# Altium schematic sheet Cell Balancing.SchDoc
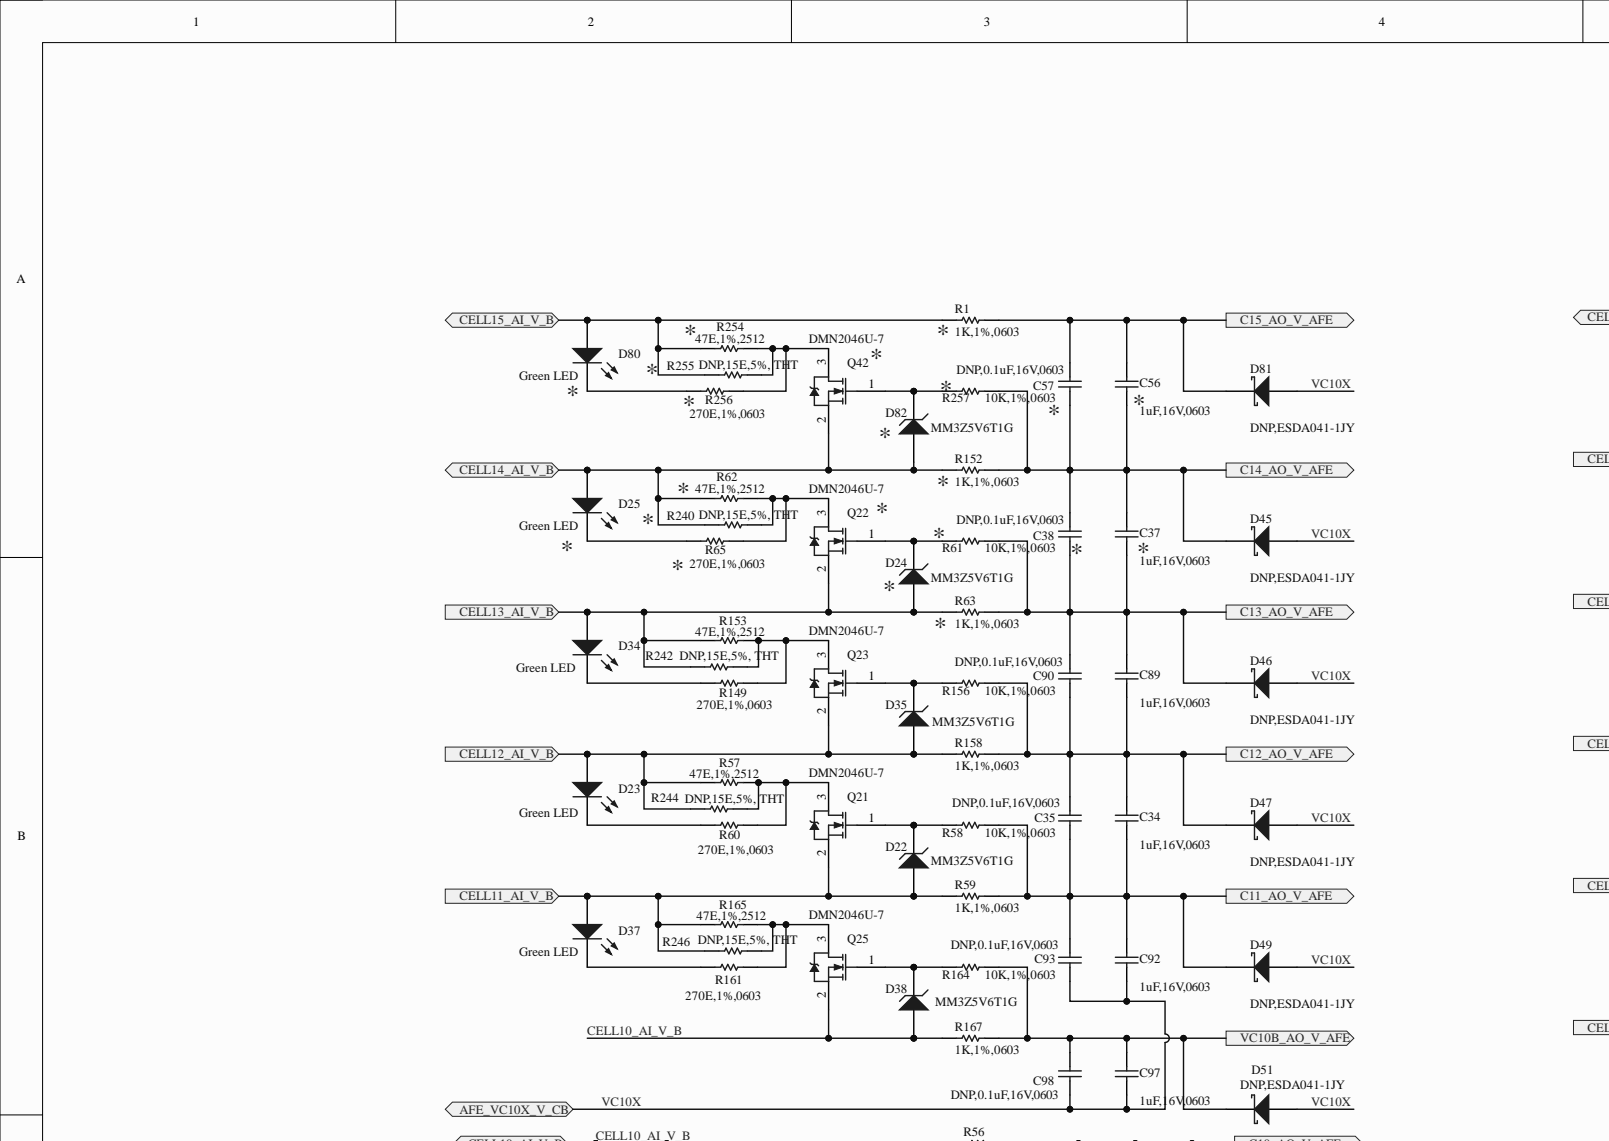
[diagram p0: Cell Balancing.SchDoc, top left]
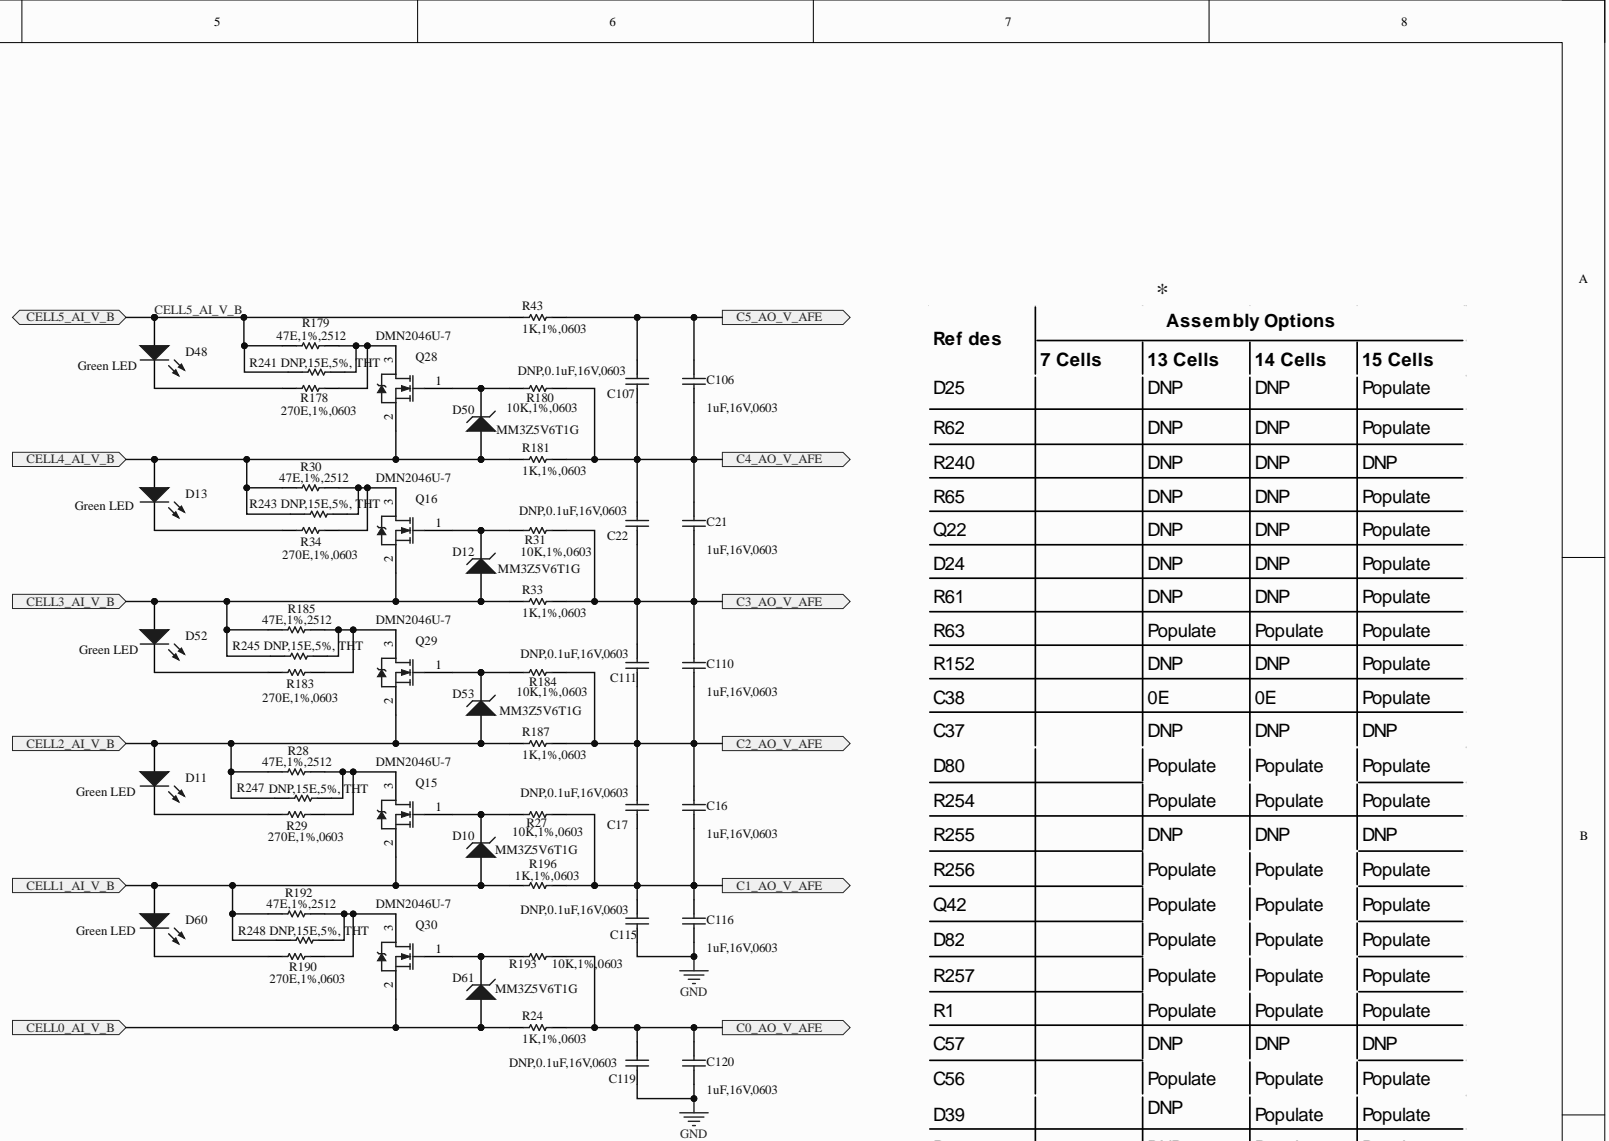
[diagram p1: Cell Balancing.SchDoc, top right]
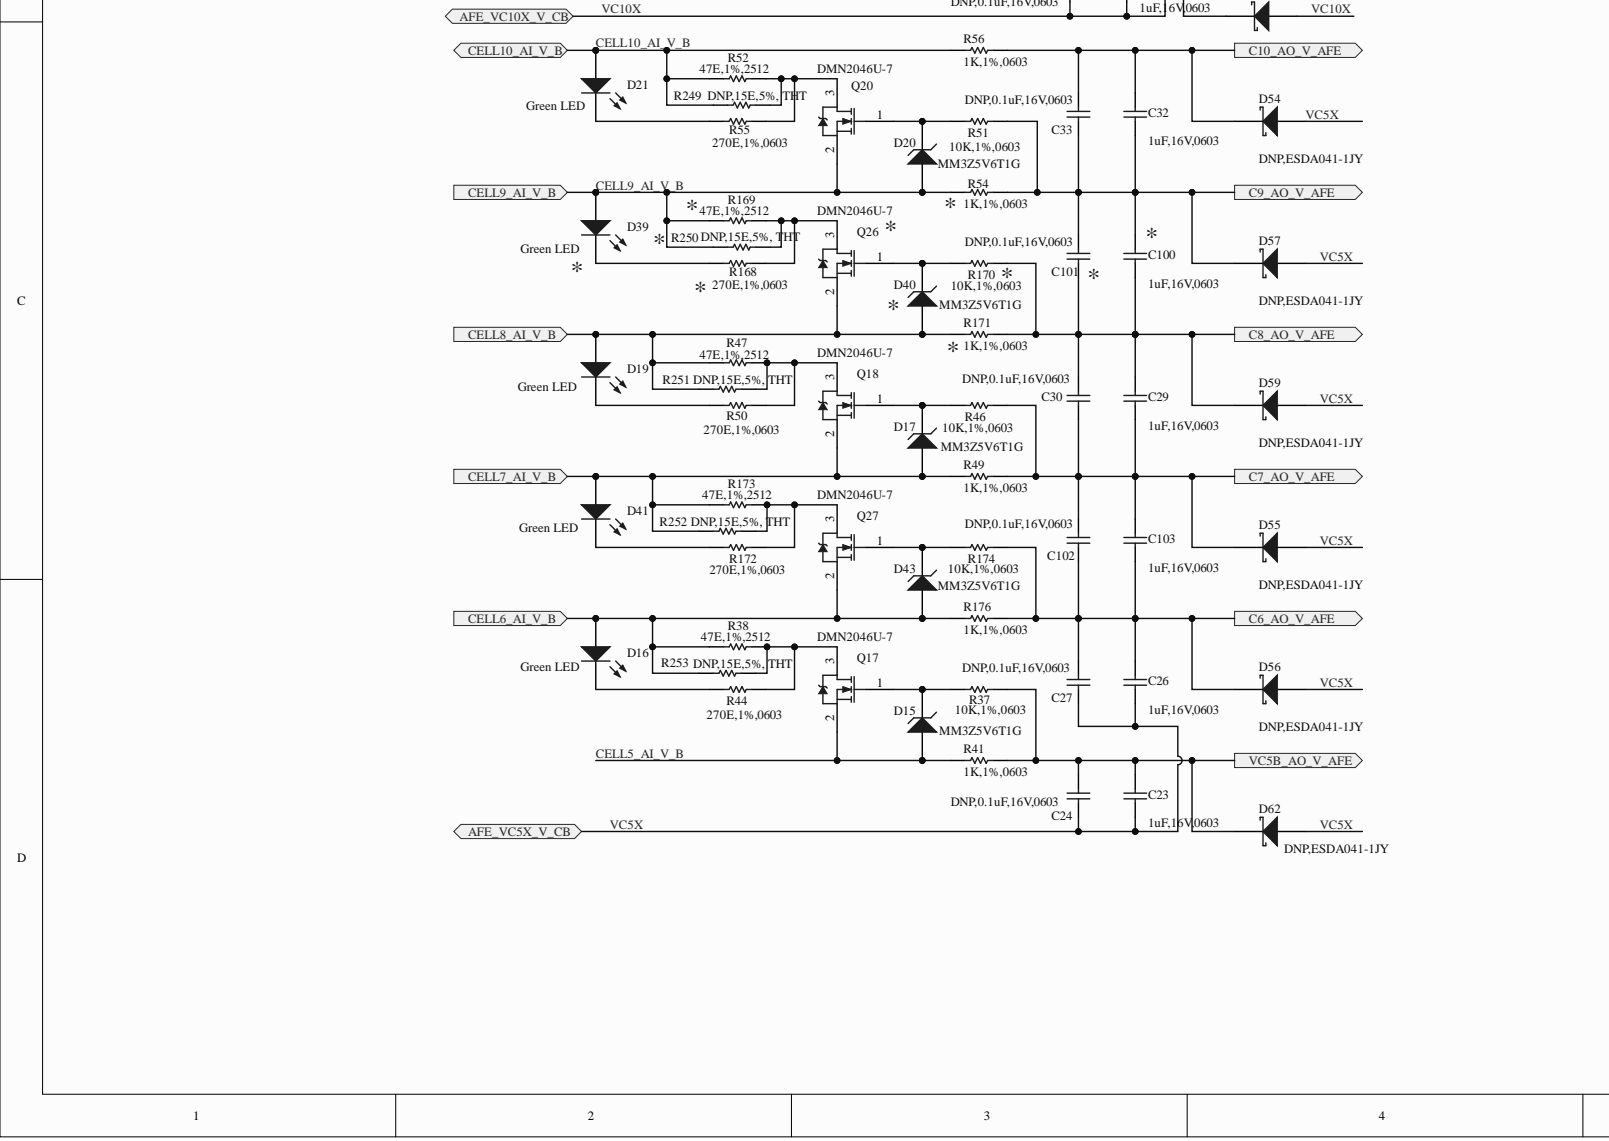
[diagram p2: Cell Balancing.SchDoc, bottom left]
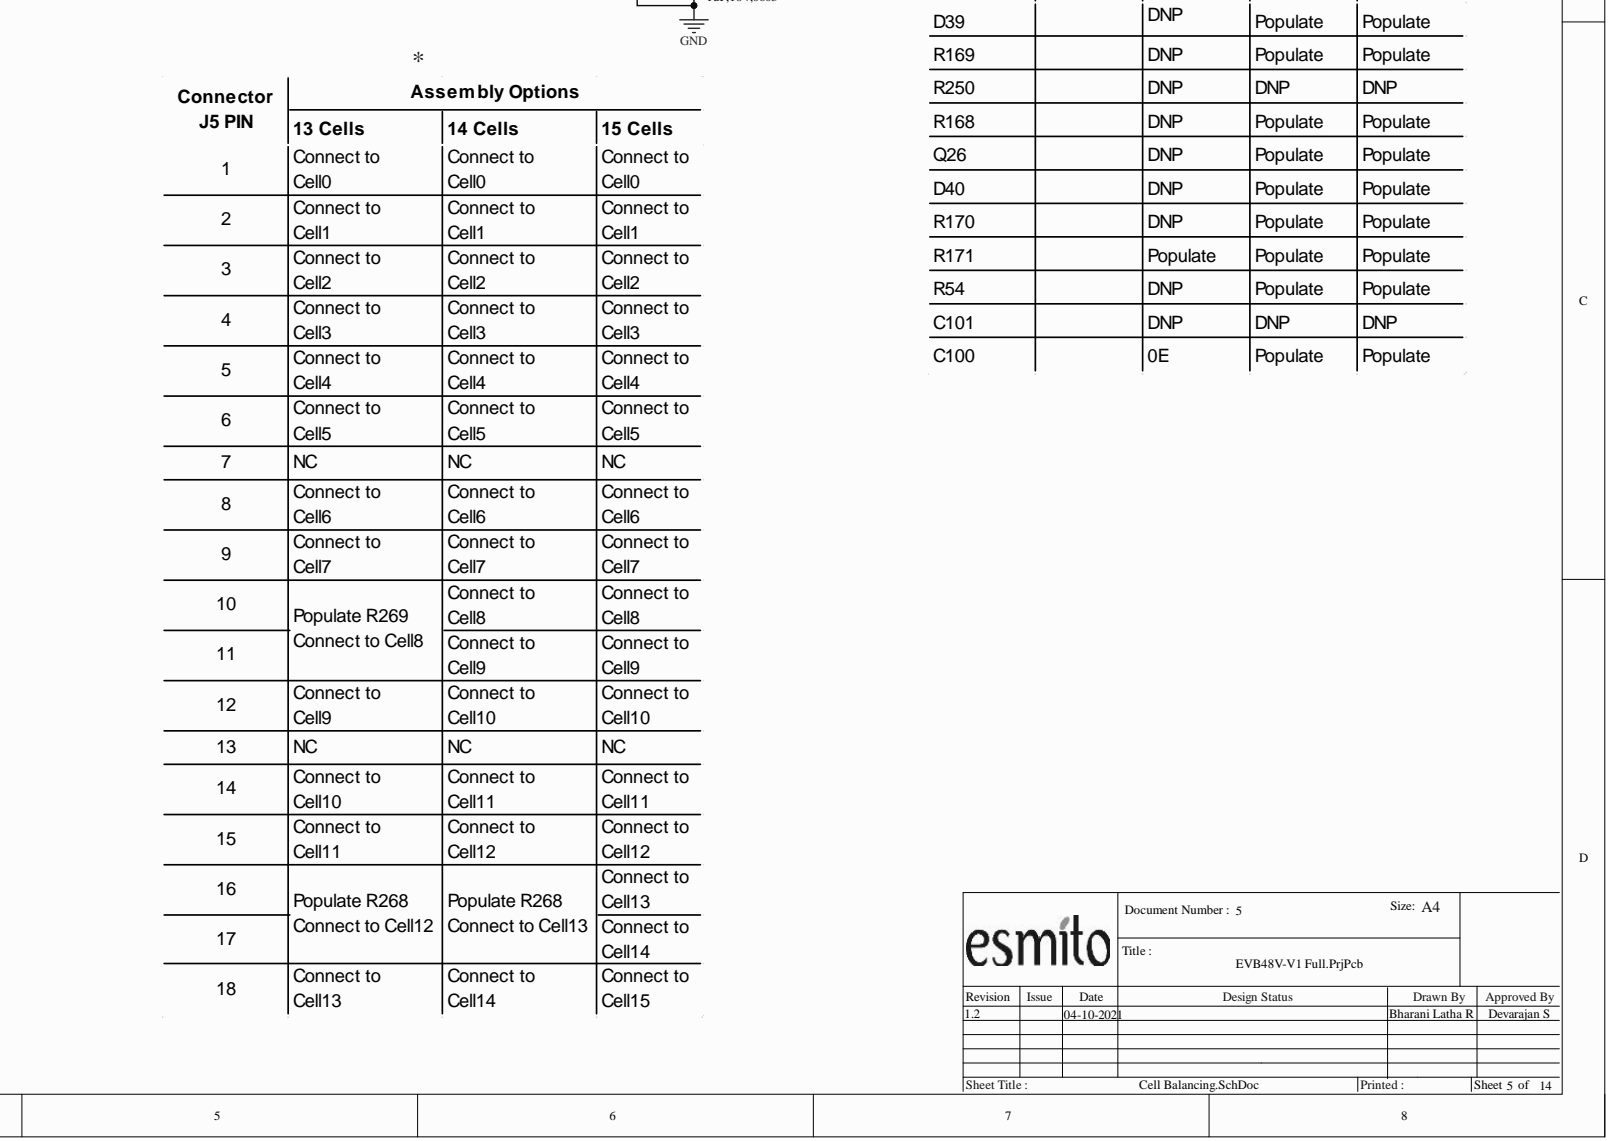
[diagram p3: Cell Balancing.SchDoc, bottom right]

## Parts
C16  value 1uF,16V,0603  [0603_C_M]  (hidden comment: C_Semi)
C17  value DNP,0.1uF,16V,0603  [0603_C_M]  (hidden comment: C_Semi)
C21  value 1uF,16V,0603  [0603_C_M]  (hidden comment: C_Semi)
C22  value DNP,0.1uF,16V,0603  [0603_C_M]  (hidden comment: C_Semi)
C23  value 1uF,16V,0603  [0603_C_M]  (hidden comment: C_Semi)
C24  value DNP,0.1uF,16V,0603  [0603_C_M]  (hidden comment: C_Semi)
C26  value 1uF,16V,0603  [0603_C_M]  (hidden comment: C_Semi)
C27  value DNP,0.1uF,16V,0603  [0603_C_M]  (hidden comment: C_Semi)
C29  value 1uF,16V,0603  [0603_C_M]  (hidden comment: C_Semi)
C30  value DNP,0.1uF,16V,0603  [0603_C_M]  (hidden comment: C_Semi)
C32  value 1uF,16V,0603  [0603_C_M]  (hidden comment: C_Semi)
C33  value DNP,0.1uF,16V,0603  [0603_C_M]  (hidden comment: C_Semi)
C34  value 1uF,16V,0603  [0603_C_M]  (hidden comment: C_Semi)
C35  value DNP,0.1uF,16V,0603  [0603_C_M]  (hidden comment: C_Semi)
C37  value 1uF,16V,0603  [0603_C_M]  (hidden comment: C_Semi)
C38  value DNP,0.1uF,16V,0603  [0603_C_M]  (hidden comment: C_Semi)
C56  value 1uF,16V,0603  [0603_C_M]  (hidden comment: C_Semi)
C57  value DNP,0.1uF,16V,0603  [0603_C_M]  (hidden comment: C_Semi)
C89  value 1uF,16V,0603  [0603_C_M]  (hidden comment: C_Semi)
C90  value DNP,0.1uF,16V,0603  [0603_C_M]  (hidden comment: C_Semi)
C92  value 1uF,16V,0603  [0603_C_M]  (hidden comment: C_Semi)
C93  value DNP,0.1uF,16V,0603  [0603_C_M]  (hidden comment: C_Semi)
C97  value 1uF,16V,0603  [0603_C_M]  (hidden comment: C_Semi)
C98  value DNP,0.1uF,16V,0603  [0603_C_M]  (hidden comment: C_Semi)
C100  value 1uF,16V,0603  [0603_C_M]  (hidden comment: C_Semi)
C101  value DNP,0.1uF,16V,0603  [0603_C_M]  (hidden comment: C_Semi)
C102  value DNP,0.1uF,16V,0603  [0603_C_M]  (hidden comment: C_Semi)
C103  value 1uF,16V,0603  [0603_C_M]  (hidden comment: C_Semi)
C106  value 1uF,16V,0603  [0603_C_M]  (hidden comment: C_Semi)
C107  value DNP,0.1uF,16V,0603  [0603_C_M]  (hidden comment: C_Semi)
C110  value 1uF,16V,0603  [0603_C_M]  (hidden comment: C_Semi)
C111  value DNP,0.1uF,16V,0603  [0603_C_M]  (hidden comment: C_Semi)
C115  value DNP,0.1uF,16V,0603  [0603_C_M]  (hidden comment: C_Semi)
C116  value 1uF,16V,0603  [0603_C_M]  (hidden comment: C_Semi)
C119  value DNP,0.1uF,16V,0603  [0603_C_M]  (hidden comment: C_Semi)
C120  value 1uF,16V,0603  [0603_C_M]  (hidden comment: C_Semi)
D10  value MM3Z5V6T1G  Part Number: MM3Z5V6T1G  [SOD_323_M]  (hidden comment: D_Zener)
D11  value Green LED  [0603_LED_M]  (hidden comment: LED0)
D12  value MM3Z5V6T1G  Part Number: MM3Z5V6T1G  [SOD_323_M]  (hidden comment: D_Zener)
D13  value Green LED  [0603_LED_M]  (hidden comment: LED0)
D15  value MM3Z5V6T1G  Part Number: MM3Z5V6T1G  [SOD_323_M]  (hidden comment: D_Zener)
D16  value Green LED  [0603_LED_M]  (hidden comment: LED0)
D17  value MM3Z5V6T1G  Part Number: MM3Z5V6T1G  [SOD_323_M]  (hidden comment: D_Zener)
D19  value Green LED  [0603_LED_M]  (hidden comment: LED0)
D20  value MM3Z5V6T1G  Part Number: MM3Z5V6T1G  [SOD_323_M]  (hidden comment: D_Zener)
D21  value Green LED  [0603_LED_M]  (hidden comment: LED0)
D22  value MM3Z5V6T1G  Part Number: MM3Z5V6T1G  [SOD_323_M]  (hidden comment: D_Zener)
D23  value Green LED  [0603_LED_M]  (hidden comment: LED0)
D24  value MM3Z5V6T1G  Part Number: MM3Z5V6T1G  [SOD_323_M]  (hidden comment: D_Zener)
D25  value Green LED  [0603_LED_M]  (hidden comment: LED0)
D34  value Green LED  [0603_LED_M]  (hidden comment: LED0)
D35  value MM3Z5V6T1G  Part Number: MM3Z5V6T1G  [SOD_323_M]  (hidden comment: D_Zener)
D37  value Green LED  [0603_LED_M]  (hidden comment: LED0)
D38  value MM3Z5V6T1G  Part Number: MM3Z5V6T1G  [SOD_323_M]  (hidden comment: D_Zener)
D39  value Green LED  [0603_LED_M]  (hidden comment: LED0)
D40  value MM3Z5V6T1G  Part Number: MM3Z5V6T1G  [SOD_323_M]  (hidden comment: D_Zener)
D41  value Green LED  [0603_LED_M]  (hidden comment: LED0)
D43  value MM3Z5V6T1G  Part Number: MM3Z5V6T1G  [SOD_323_M]  (hidden comment: D_Zener)
D45  value DNP,ESDA041-1JY  [SOD_323_M]  (hidden comment: D_Schottky)
D46  value DNP,ESDA041-1JY  [SOD_323_M]  (hidden comment: D_Schottky)
D47  value DNP,ESDA041-1JY  [SOD_323_M]  (hidden comment: D_Schottky)
D48  value Green LED  [0603_LED_M]  (hidden comment: LED0)
D49  value DNP,ESDA041-1JY  [SOD_323_M]  (hidden comment: D_Schottky)
D50  value MM3Z5V6T1G  Part Number: MM3Z5V6T1G  [SOD_323_M]  (hidden comment: D_Zener)
D51  value DNP,ESDA041-1JY  [SOD_323_M]  (hidden comment: D_Schottky)
D52  value Green LED  [0603_LED_M]  (hidden comment: LED0)
D53  value MM3Z5V6T1G  Part Number: MM3Z5V6T1G  [SOD_323_M]  (hidden comment: D_Zener)
D54  value DNP,ESDA041-1JY  [SOD_323_M]  (hidden comment: D_Schottky)
D55  value DNP,ESDA041-1JY  [SOD_323_M]  (hidden comment: D_Schottky)
D56  value DNP,ESDA041-1JY  [SOD_323_M]  (hidden comment: D_Schottky)
D57  value DNP,ESDA041-1JY  [SOD_323_M]  (hidden comment: D_Schottky)
D59  value DNP,ESDA041-1JY  [SOD_323_M]  (hidden comment: D_Schottky)
D60  value Green LED  [0603_LED_M]  (hidden comment: LED0)
D61  value MM3Z5V6T1G  Part Number: MM3Z5V6T1G  [SOD_323_M]  (hidden comment: D_Zener)
D62  value DNP,ESDA041-1JY  [SOD_323_M]  (hidden comment: D_Schottky)
D80  value Green LED  [0603_LED_M]  (hidden comment: LED0)
D81  value DNP,ESDA041-1JY  [SOD_323_M]  (hidden comment: D_Schottky)
D82  value MM3Z5V6T1G  Part Number: MM3Z5V6T1G  [SOD_323_M]  (hidden comment: D_Zener)
Q15  value DMN2046U-7  Part Number: DMN2046U-7  [SOT23_3_L]  (hidden comment: MOS-N_E_Power)
Q16  value DMN2046U-7  Part Number: DMN2046U-7  [SOT23_3_L]  (hidden comment: MOS-N_E_Power)
Q17  value DMN2046U-7  Part Number: DMN2046U-7  [SOT23_3_L]  (hidden comment: MOS-N_E_Power)
Q18  value DMN2046U-7  Part Number: DMN2046U-7  [SOT23_3_L]  (hidden comment: MOS-N_E_Power)
Q20  value DMN2046U-7  Part Number: DMN2046U-7  [SOT23_3_L]  (hidden comment: MOS-N_E_Power)
Q21  value DMN2046U-7  Part Number: DMN2046U-7  [SOT23_3_L]  (hidden comment: MOS-N_E_Power)
Q22  value DMN2046U-7  Part Number: DMN2046U-7  [SOT23_3_L]  (hidden comment: MOS-N_E_Power)
Q23  value DMN2046U-7  Part Number: DMN2046U-7  [SOT23_3_L]  (hidden comment: MOS-N_E_Power)
Q25  value DMN2046U-7  Part Number: DMN2046U-7  [SOT23_3_L]  (hidden comment: MOS-N_E_Power)
Q26  value DMN2046U-7  Part Number: DMN2046U-7  [SOT23_3_L]  (hidden comment: MOS-N_E_Power)
Q27  value DMN2046U-7  Part Number: DMN2046U-7  [SOT23_3_L]  (hidden comment: MOS-N_E_Power)
Q28  value DMN2046U-7  Part Number: DMN2046U-7  [SOT23_3_L]  (hidden comment: MOS-N_E_Power)
Q29  value DMN2046U-7  Part Number: DMN2046U-7  [SOT23_3_L]  (hidden comment: MOS-N_E_Power)
Q30  value DMN2046U-7  Part Number: DMN2046U-7  [SOT23_3_L]  (hidden comment: MOS-N_E_Power)
Q42  value DMN2046U-7  Part Number: DMN2046U-7  [SOT23_3_L]  (hidden comment: MOS-N_E_Power)
R1  value 1K,1%,0603  [0603_R_M]  (hidden comment: R_Semi)
R24  value 1K,1%,0603  [0603_R_M]  (hidden comment: R_Semi)
R27  value 10K,1%,0603  [0603_R_M]  (hidden comment: R_Semi)
R28  value 47E,1%,2512  [2512_R_M]  (hidden comment: R_Semi)
R29  value 270E,1%,0603  [0603_R_M]  (hidden comment: R_Semi)
R30  value 47E,1%,2512  [2512_R_M]  (hidden comment: R_Semi)
R31  value 10K,1%,0603  [0603_R_M]  (hidden comment: R_Semi)
R33  value 1K,1%,0603  [0603_R_M]  (hidden comment: R_Semi)
R34  value 270E,1%,0603  [0603_R_M]  (hidden comment: R_Semi)
R37  value 10K,1%,0603  [0603_R_M]  (hidden comment: R_Semi)
R38  value 47E,1%,2512  [2512_R_M]  (hidden comment: R_Semi)
R41  value 1K,1%,0603  [0603_R_M]  (hidden comment: R_Semi)
R43  value 1K,1%,0603  [0603_R_M]  (hidden comment: R_Semi)
R44  value 270E,1%,0603  [0603_R_M]  (hidden comment: R_Semi)
R46  value 10K,1%,0603  [0603_R_M]  (hidden comment: R_Semi)
R47  value 47E,1%,2512  [2512_R_M]  (hidden comment: R_Semi)
R49  value 1K,1%,0603  [0603_R_M]  (hidden comment: R_Semi)
R50  value 270E,1%,0603  [0603_R_M]  (hidden comment: R_Semi)
R51  value 10K,1%,0603  [0603_R_M]  (hidden comment: R_Semi)
R52  value 47E,1%,2512  [2512_R_M]  (hidden comment: R_Semi)
R54  value 1K,1%,0603  [0603_R_M]  (hidden comment: R_Semi)
R55  value 270E,1%,0603  [0603_R_M]  (hidden comment: R_Semi)
R56  value 1K,1%,0603  [0603_R_M]  (hidden comment: R_Semi)
R57  value 47E,1%,2512  [2512_R_M]  (hidden comment: R_Semi)
R58  value 10K,1%,0603  [0603_R_M]  (hidden comment: R_Semi)
R59  value 1K,1%,0603  [0603_R_M]  (hidden comment: R_Semi)
R60  value 270E,1%,0603  [0603_R_M]  (hidden comment: R_Semi)
R61  value 10K,1%,0603  [0603_R_M]  (hidden comment: R_Semi)
R62  value 47E,1%,2512  [2512_R_M]  (hidden comment: R_Semi)
R63  value 1K,1%,0603  [0603_R_M]  (hidden comment: R_Semi)
R65  value 270E,1%,0603  [0603_R_M]  (hidden comment: R_Semi)
R149  value 270E,1%,0603  [0603_R_M]  (hidden comment: R_Semi)
R152  value 1K,1%,0603  [0603_R_M]  (hidden comment: R_Semi)
R153  value 47E,1%,2512  [2512_R_M]  (hidden comment: R_Semi)
R156  value 10K,1%,0603  [0603_R_M]  (hidden comment: R_Semi)
R158  value 1K,1%,0603  [0603_R_M]  (hidden comment: R_Semi)
R161  value 270E,1%,0603  [0603_R_M]  (hidden comment: R_Semi)
R164  value 10K,1%,0603  [0603_R_M]  (hidden comment: R_Semi)
R165  value 47E,1%,2512  [2512_R_M]  (hidden comment: R_Semi)
R167  value 1K,1%,0603  [0603_R_M]  (hidden comment: R_Semi)
R168  value 270E,1%,0603  [0603_R_M]  (hidden comment: R_Semi)
R169  value 47E,1%,2512  [2512_R_M]  (hidden comment: R_Semi)
R170  value 10K,1%,0603  [0603_R_M]  (hidden comment: R_Semi)
R171  value 1K,1%,0603  [0603_R_M]  (hidden comment: R_Semi)
R172  value 270E,1%,0603  [0603_R_M]  (hidden comment: R_Semi)
R173  value 47E,1%,2512  [2512_R_M]  (hidden comment: R_Semi)
R174  value 10K,1%,0603  [0603_R_M]  (hidden comment: R_Semi)
R176  value 1K,1%,0603  [0603_R_M]  (hidden comment: R_Semi)
R178  value 270E,1%,0603  [0603_R_M]  (hidden comment: R_Semi)
R179  value 47E,1%,2512  [2512_R_M]  (hidden comment: R_Semi)
R180  value 10K,1%,0603  [0603_R_M]  (hidden comment: R_Semi)
R181  value 1K,1%,0603  [0603_R_M]  (hidden comment: R_Semi)
R183  value 270E,1%,0603  [0603_R_M]  (hidden comment: R_Semi)
R184  value 10K,1%,0603  [0603_R_M]  (hidden comment: R_Semi)
R185  value 47E,1%,2512  [2512_R_M]  (hidden comment: R_Semi)
R187  value 1K,1%,0603  [0603_R_M]  (hidden comment: R_Semi)
R190  value 270E,1%,0603  [0603_R_M]  (hidden comment: R_Semi)
R192  value 47E,1%,2512  [2512_R_M]  (hidden comment: R_Semi)
R193  value 10K,1%,0603  [0603_R_M]  (hidden comment: R_Semi)
R196  value 1K,1%,0603  [0603_R_M]  (hidden comment: R_Semi)
R240  value DNP,15E,5%, THT  [1W_R_Radial]  (hidden comment: R_Semi)
R241  value DNP,15E,5%, THT  [1W_R_Radial]  (hidden comment: R_Semi)
R242  value DNP,15E,5%, THT  [1W_R_Radial]  (hidden comment: R_Semi)
R243  value DNP,15E,5%, THT  [1W_R_Radial]  (hidden comment: R_Semi)
R244  value DNP,15E,5%, THT  [1W_R_Radial]  (hidden comment: R_Semi)
R245  value DNP,15E,5%, THT  [1W_R_Radial]  (hidden comment: R_Semi)
R246  value DNP,15E,5%, THT  [1W_R_Radial]  (hidden comment: R_Semi)
R247  value DNP,15E,5%, THT  [1W_R_Radial]  (hidden comment: R_Semi)
R248  value DNP,15E,5%, THT  [1W_R_Radial]  (hidden comment: R_Semi)
R249  value DNP,15E,5%, THT  [1W_R_Radial]  (hidden comment: R_Semi)
R250  value DNP,15E,5%, THT  [1W_R_Radial]  (hidden comment: R_Semi)
R251  value DNP,15E,5%, THT  [1W_R_Radial]  (hidden comment: R_Semi)
R252  value DNP,15E,5%, THT  [1W_R_Radial]  (hidden comment: R_Semi)
R253  value DNP,15E,5%, THT  [1W_R_Radial]  (hidden comment: R_Semi)
R254  value 47E,1%,2512  [2512_R_M]  (hidden comment: R_Semi)
R255  value DNP,15E,5%, THT  [1W_R_Radial]  (hidden comment: R_Semi)
R256  value 270E,1%,0603  [0603_R_M]  (hidden comment: R_Semi)
R257  value 10K,1%,0603  [0603_R_M]  (hidden comment: R_Semi)

## Ports
AFE_VC5X_V_CB, AFE_VC10X_V_CB, C0_AO_V_AFE, C1_AO_V_AFE, C2_AO_V_AFE, C3_AO_V_AFE, C4_AO_V_AFE, C5_AO_V_AFE, C6_AO_V_AFE, C7_AO_V_AFE, C8_AO_V_AFE, C9_AO_V_AFE, C10_AO_V_AFE, C11_AO_V_AFE, C12_AO_V_AFE, C13_AO_V_AFE, C14_AO_V_AFE, C15_AO_V_AFE, CELL0_AI_V_B, CELL1_AI_V_B, CELL2_AI_V_B, CELL3_AI_V_B, CELL4_AI_V_B, CELL5_AI_V_B, CELL6_AI_V_B, CELL7_AI_V_B, CELL8_AI_V_B, CELL9_AI_V_B, CELL10_AI_V_B, CELL11_AI_V_B, CELL12_AI_V_B, CELL13_AI_V_B, CELL14_AI_V_B, CELL15_AI_V_B, VC5B_AO_V_AFE, VC10B_AO_V_AFE

## Nets
GND: C115.1 C116.1 C119.1 C120.1
C0_AO_V_AFE: C119.2 C120.2 R24.2 R193.2 ; port C0_AO_V_AFE
C1_AO_V_AFE: C16.1 C17.1 C115.2 C116.2 R27.2 R196.2 ; port C1_AO_V_AFE
C2_AO_V_AFE: C16.2 C17.2 C110.1 C111.1 R184.2 R187.2 ; port C2_AO_V_AFE
C3_AO_V_AFE: C21.1 C22.1 C110.2 C111.2 R31.2 R33.2 ; port C3_AO_V_AFE
C4_AO_V_AFE: C21.2 C22.2 C106.1 C107.1 R180.2 R181.2 ; port C4_AO_V_AFE
C5_AO_V_AFE: C106.2 C107.2 R43.2 ; port C5_AO_V_AFE
C6_AO_V_AFE: C26.2 C27.2 C102.1 C103.1 D56.2 R174.2 R176.2 ; port C6_AO_V_AFE
C7_AO_V_AFE: C29.1 C30.1 C102.2 C103.2 D55.2 R46.2 R49.2 ; port C7_AO_V_AFE
C8_AO_V_AFE: C29.2 C30.2 C100.1 C101.1 D59.2 R170.2 R171.2 ; port C8_AO_V_AFE
C9_AO_V_AFE: C32.1 C33.1 C100.2 C101.2 D57.2 R51.2 R54.2 ; port C9_AO_V_AFE
C10_AO_V_AFE: C32.2 C33.2 D54.2 R56.2 ; port C10_AO_V_AFE
C11_AO_V_AFE: C34.1 C35.1 C92.2 C93.2 D49.2 R58.2 R59.2 ; port C11_AO_V_AFE
C12_AO_V_AFE: C34.2 C35.2 C89.1 C90.1 D47.2 R156.2 R158.2 ; port C12_AO_V_AFE
C13_AO_V_AFE: C37.1 C38.1 C89.2 C90.2 D46.2 R61.2 R63.2 ; port C13_AO_V_AFE
C14_AO_V_AFE: C37.2 C38.2 C56.1 C57.1 D45.2 R152.2 R257.2 ; port C14_AO_V_AFE
C15_AO_V_AFE: C56.2 C57.2 D81.2 R1.2 ; port C15_AO_V_AFE
CELL0_AI_V_B: D61.1 Q30.2 R24.1 ; port CELL0_AI_V_B
CELL1_AI_V_B: D10.1 D60.1 Q15.2 R192.1 R196.1 R248.2 ; port CELL1_AI_V_B
CELL2_AI_V_B: D11.1 D53.1 Q29.2 R28.1 R187.1 R247.1 ; port CELL2_AI_V_B
CELL3_AI_V_B: D12.1 D52.1 Q16.2 R33.1 R185.1 R245.2 ; port CELL3_AI_V_B
CELL4_AI_V_B: D13.1 D50.1 Q28.2 R30.1 R181.1 R243.1 ; port CELL4_AI_V_B
CELL5_AI_V_B: D15.1 D48.1 Q17.2 R41.1 R43.1 R179.1 R241.2 ; port CELL5_AI_V_B
CELL6_AI_V_B: D16.1 D43.1 Q27.2 R38.1 R176.1 R253.1 ; port CELL6_AI_V_B
CELL7_AI_V_B: D17.1 D41.1 Q18.2 R49.1 R173.1 R252.2 ; port CELL7_AI_V_B
CELL8_AI_V_B: D19.1 D40.1 Q26.2 R47.1 R171.1 R251.1 ; port CELL8_AI_V_B
CELL9_AI_V_B: D20.1 D39.1 Q20.2 R54.1 R169.1 R250.2 ; port CELL9_AI_V_B
CELL10_AI_V_B: D21.1 D38.1 Q25.2 R52.1 R56.1 R167.1 R249.1 ; port CELL10_AI_V_B
CELL11_AI_V_B: D22.1 D37.1 Q21.2 R59.1 R165.1 R246.2 ; port CELL11_AI_V_B
CELL12_AI_V_B: D23.1 D35.1 Q23.2 R57.1 R158.1 R244.1 ; port CELL12_AI_V_B
CELL13_AI_V_B: D24.1 D34.1 Q22.2 R63.1 R153.1 R242.2 ; port CELL13_AI_V_B
CELL14_AI_V_B: D25.1 D82.1 Q42.2 R62.1 R152.1 R240.1 ; port CELL14_AI_V_B
CELL15_AI_V_B: D80.1 R1.1 R254.1 R255.1 ; port CELL15_AI_V_B
VC5B_AO_V_AFE: C23.2 C24.2 D62.2 R37.2 R41.2 ; port VC5B_AO_V_AFE
VC5X: C23.1 C24.1 C26.1 C27.1 D54.1 D55.1 D56.1 D57.1 D59.1 D62.1 ; port AFE_VC5X_V_CB
VC10B_AO_V_AFE: C97.2 C98.2 D51.2 R164.2 R167.2 ; port VC10B_AO_V_AFE
VC10X: C92.1 C93.1 C97.1 C98.1 D45.1 D46.1 D47.1 D49.1 D51.1 D81.1 ; port AFE_VC10X_V_CB
NetD10_2: D10.2 Q15.1 R27.1
NetD11_2: D11.2 R29.2
NetD12_2: D12.2 Q16.1 R31.1
NetD13_2: D13.2 R34.2
NetD15_2: D15.2 Q17.1 R37.1
NetD16_2: D16.2 R44.1
NetD17_2: D17.2 Q18.1 R46.1
NetD19_2: D19.2 R50.2
NetD20_2: D20.2 Q20.1 R51.1
NetD21_2: D21.2 R55.2
NetD22_2: D22.2 Q21.1 R58.1
NetD23_2: D23.2 R60.2
NetD24_2: D24.2 Q22.1 R61.1
NetD25_2: D25.2 R65.2
NetD34_2: D34.2 R149.2
NetD35_2: D35.2 Q23.1 R156.1
NetD37_2: D37.2 R161.1
NetD38_2: D38.2 Q25.1 R164.1
NetD39_2: D39.2 R168.2
NetD40_2: D40.2 Q26.1 R170.1
NetD41_2: D41.2 R172.2
NetD43_2: D43.2 Q27.1 R174.1
NetD48_2: D48.2 R178.2
NetD50_2: D50.2 Q28.1 R180.1
NetD52_2: D52.2 R183.2
NetD53_2: D53.2 Q29.1 R184.1
NetD60_2: D60.2 R190.2
NetD61_2: D61.2 Q30.1 R193.1
NetD80_2: D80.2 R256.2
NetD82_2: D82.2 Q42.1 R257.1
NetQ15_3: Q15.3 R28.2 R29.1 R247.2
NetQ16_3: Q16.3 R30.2 R34.1 R243.2
NetQ17_3: Q17.3 R38.2 R44.2 R253.2
NetQ18_3: Q18.3 R47.2 R50.1 R251.2
NetQ20_3: Q20.3 R52.2 R55.1 R249.2
NetQ21_3: Q21.3 R57.2 R60.1 R244.2
NetQ22_3: Q22.3 R62.2 R65.1 R240.2
NetQ23_3: Q23.3 R149.1 R153.2 R242.1
NetQ25_3: Q25.3 R161.2 R165.2 R246.1
NetQ26_3: Q26.3 R168.1 R169.2 R250.1
NetQ27_3: Q27.3 R172.1 R173.2 R252.1
NetQ28_3: Q28.3 R178.1 R179.2 R241.1
NetQ29_3: Q29.3 R183.1 R185.2 R245.1
NetQ30_3: Q30.3 R190.1 R192.2 R248.1
NetQ42_3: Q42.3 R254.2 R255.2 R256.1

## Library data of the parts
C16 C21 C23 C26 C29 C32 C34 C37 C56 C89 C92 C97 C100 C103 C106 C110 C116 C120 = C_Semi: MLCC - 0603 1uF 16V X5R 10%; Alternate Manufacturer: Murata; Alternate Part Number: GCM188R71C105KA64D; Manufacturer: Yageo; Part Number: CC603KRX5R7BB105; Component Kind: Standard; Signal Integrity: Cap Semi; Simulation: CAP; Library Name: Grinntech_Global_Library.IntLib
C17 C22 C24 C27 C30 C33 C35 C38 C57 C90 C93 C98 C101 C102 C107 C111 C115 C119 = C_Semi: MLCC - 0603 100nF 16V X7R 10%; Alternate Manufacturer: AVX; Alternate Part Number: 0603YC104K4T2A; Manufacturer: Yageo; Part Number: CC603KRX7R7BB104; Component Kind: Standard; Signal Integrity: Cap Semi; Simulation: CAP; Library Name: Grinntech_Global_Library.IntLib
D10 D12 D15 D17 D20 D22 D24 D35 D38 D40 D43 D50 D53 D61 D82 = D_Zener: Diode, Zener, 5.6V, 5mA, SOD-323-2; Alternate Manufacturer: Diodes Incorporated; Alternate Part Number: UDZ5V6B-7; Manufacturer: ON Semiconductor; Component Kind: Standard; Signal Integrity: D Zener; Simulation: ZENER; Library Name: Grinntech_Global_Library.IntLib
D11 D13 D16 D19 D21 D23 D25 D34 D37 D39 D41 D48 D52 D60 D80 = LED0: Diode, Led, 2V, 20mA, 0603; Alternate Manufacturer: Lite-ON; Alternate Part Number: LTST-C190KGKT; Manufacturer: ROHM; Part Number: SML-D12M8WT86; Component Kind: Standard; Simulation: LED0; Library Name: Grinntech_Global_Library.IntLib
D45-D47 D49 D51 D54-D57 D59 D62 D81 = D_Schottky: Diode, TVS, 5V, SOD323; Manufacturer: STMicroelectronics; Part Number: ESDA041-1JY; Component Kind: Standard; Signal Integrity: D Schottky; Simulation: SKYDIODE; Library Name: Grinntech_Global_Library.IntLib
Q15-Q18 Q20-Q23 Q25-Q30 Q42 = MOS-N_E_Power: MOSFET, N-CH, 20V, 3.4A, SOT-23-3; Manufacturer: Diodes Incorporated; Component Kind: Standard; Simulation: IRF1010; Library Name: CLVESB-R10.SCHLIB
R1 R24 R33 R41 R43 R49 R54 R56 R59 R63 R152 R158 R167 R171 R176 R181 R187 R196 = R_Semi: R, THK FLM, 1K, 1%, 100mW, 0603; Alternate Manufacturer: Vishay; Alternate Part Number: CRCW06031K00FKEA; Manufacturer: Yageo; Part Number: RC0603FR-071KL; Component Kind: Standard; Signal Integrity: Res Semi; Simulation: RES; Library Name: Grinntech_Global_Library.IntLib
R27 R31 R37 R46 R51 R58 R61 R156 R164 R170 R174 R180 R184 R193 R257 = R_Semi: R, THK FLM, 10K, 1%, 100mW, 0603; Alternate Manufacturer: Vishay; Alternate Part Number: CRCW060310K0FKEA; Manufacturer: Yageo; Part Number: RC0603FR-0710KL; Component Kind: Standard; Signal Integrity: Res Semi; Simulation: RES; Library Name: Grinntech_Global_Library.IntLib
R28 R30 R38 R47 R52 R57 R62 R153 R165 R169 R173 R179 R185 R192 R254 = R_Semi: R, THK FLM, 47E, 1%, 1W, 2512; Alternate Manufacturer: TE Connectivity; Alternate Part Number: CRGCQ2512J47R; Manufacturer: Yageo; Part Number: RC2512FK-0747RL; Component Kind: Standard; Signal Integrity: Res Semi; Simulation: RES; Library Name: Grinntech_Global_Library.IntLib
R29 R34 R44 R50 R55 R60 R65 R149 R161 R168 R172 R178 R183 R190 R256 = R_Semi: R, THK FLM, 270E, 1%, 100mW, 0603; Alternate Manufacturer: KOA; Alternate Part Number: RK73H1JTTD2700F; Manufacturer: Yageo; Part Number: RC0603FR-10270RL; Component Kind: Standard; Signal Integrity: Res Semi; Simulation: RES; Library Name: Grinntech_Global_Library.IntLib
R240 R242 R244-R253 R255 = R_Semi: R, 15E, 5%, 1W, THT; Manufacturer: Hi-Tech Res; Part Number: HAA A2*PVJ15R; Component Kind: Standard; Signal Integrity: Res Semi; Simulation: RES; Library Name: Grinntech_Global_Library.IntLib
R241 R243 = R_Semi: R, 15E, 5%, 1W, THT; Manufacturer: Hi-Tech Res; Part Number: HAA A2*PVJ15R; Value: DNP,15E,5%, THT; Component Kind: Standard; Signal Integrity: Res Semi; Simulation: RES; Library Name: Grinntech_Global_Library.IntLib
